# Altium schematic sheet transmitter.SchDoc
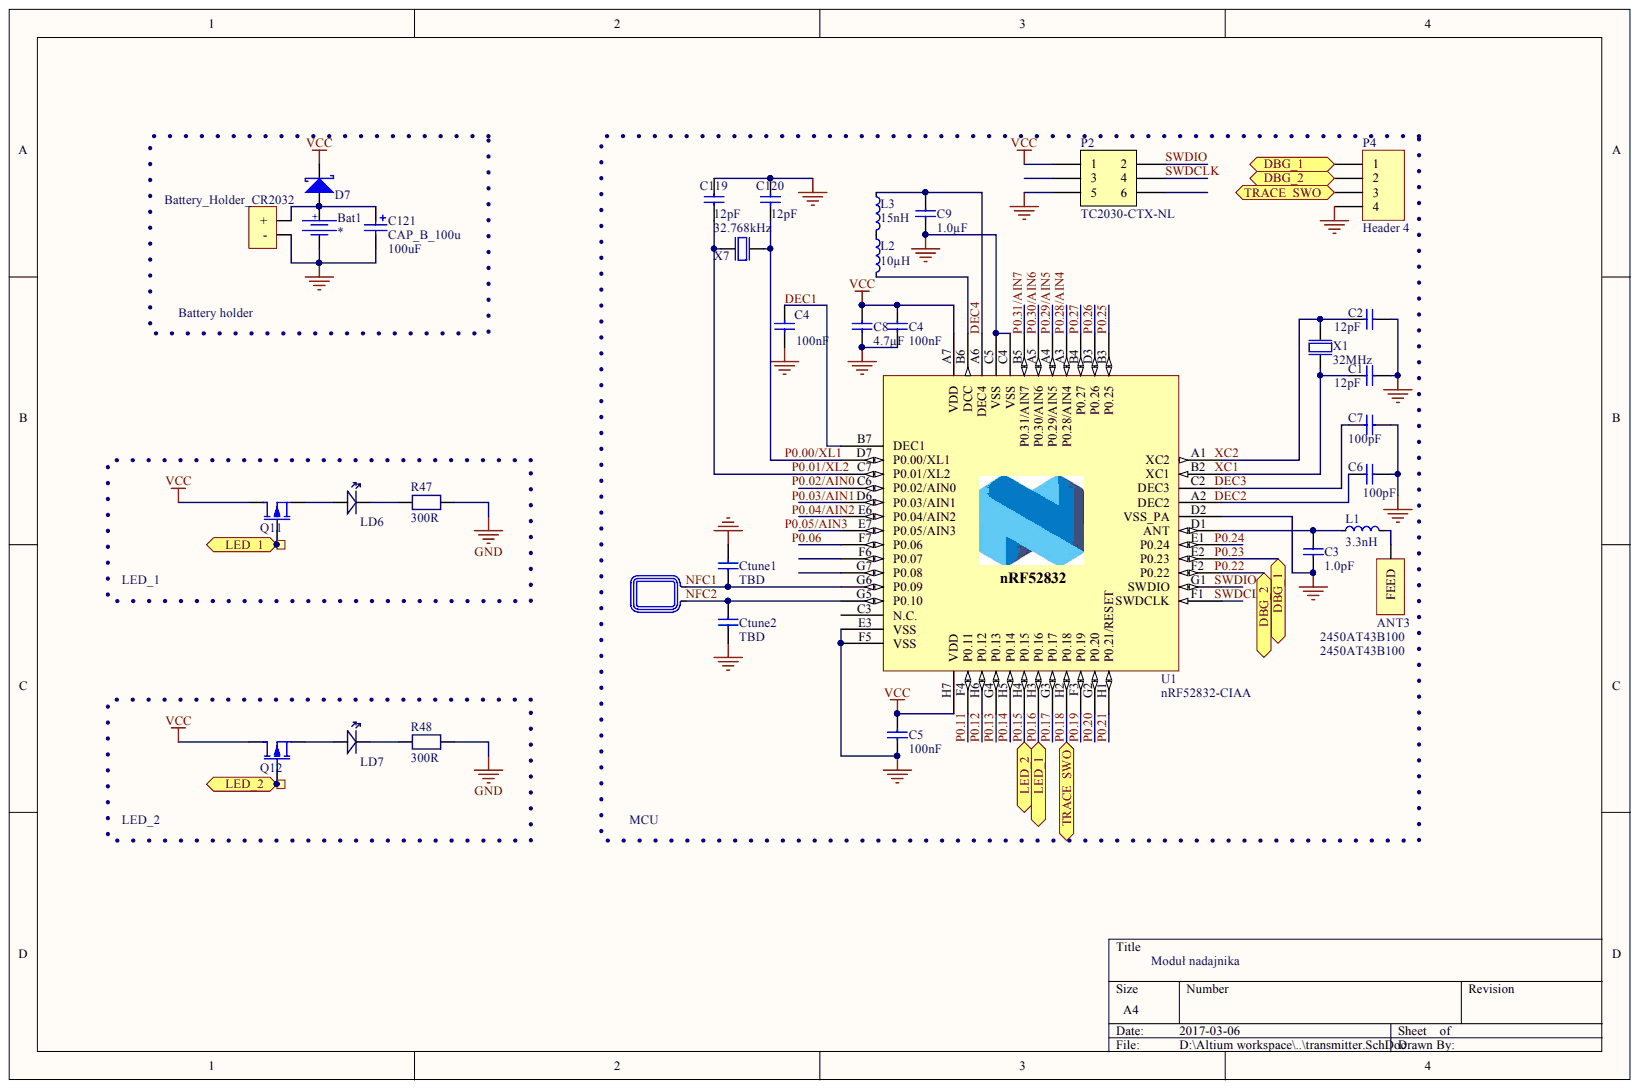
[diagram p0: transmitter.SchDoc]

## Parts
33  Ø1.0mm,SMD  [TP_SMD_Ø1.0mm]  (value not drawn)  (no BOM)
34  Ø1.0mm,SMD  [TP_SMD_Ø1.0mm]  (value not drawn)  (no BOM)
A1  NFC Antenna  [TP_2x1mm_TH]  (value not drawn)  (no BOM)
ANT3  2450AT43B100  [2450AT43B100]
Bat1  (no value)  [CR1632_HOLDER_SMD]
C1  12pF  [CAPC0603X03L]
C2  12pF  [CAPC0603X03L]
C3  1.0pF  [CAPC0603X03L]
C4  100nF  [CAPC0603X03L]
C4  100nF  [CAPC0603X03L]
C5  100nF  [CAPC0603X03L]
C6  100pF  [CAPC0603X03L]
C7  100pF  [CAPC0603X03L]
C8  4.7µF  [CAPC1608X06L]
C9  1.0µF  [CAPC1005X04L]
C119  12pF  [CAPC0603X03L]
C120  12pF  [CAPC0603X03L]
C121  CAP_B_100u  value 100uF  [B]
Ctune1  TBD  [CAPC0603X03L]
Ctune2  TBD  [CAPC0603X03L]
D7  Shottky  [DO-214-AA]  (value not drawn)
L1  3.3nH  [INDC0603X03L]
L2  10µH  [INDC1608X06L]
L3  15nH  [INDC1005X04L]
LD6  0603_LED  [LED_0603]  (value not drawn)
LD7  0603_LED  [LED_0603]  (value not drawn)
P2  TC2030-CTX-NL  [TC2030-IDC-NLFP]  (no BOM)
P4  Header 4  [HDR1X4]
Q11  RZE002P02TLTR-ND  [SOT-416]  (value not drawn)
Q12  RZE002P02TLTR-ND  [SOT-416]  (value not drawn)
R47  value 300R  [RES_0402]  (hidden comment: RES_0402_1k)
R48  value 300R  [RES_0402]  (hidden comment: RES_0402_1k)
U1  nRF52832-CIAA  [BGA50C40P7X8_295X322X50]
X1  32MHz  [BT-XTAL_2016]
X7  32.768kHz  [XTAL_2012]
  Battery_Holder_CR2032  [CR2032_holder]

## Ports
DBG_1, DBG_2, LED_1, LED_2, TRACE_SWO

## Nets
GND: Bat1.2 C1.1 C2.1 C3.1 C4.2 C5.2 C6.1 C7.2 C8.2 C9.1 C119.2 C120.2 C121.2 Ctune1.2 Ctune2.1 P2.5 P4.4 R47.1 R48.1 U1.C4(VSS) U1.C5(VSS) U1.D2(VSS_PA) U1.E3(VSS) U1.F5(VSS) X1.2 X1.4 .2
Net_1: Bat1.1 C121.1 D7.1 .1
VCC: C4.1 C5.1 C8.1 D7.2 P2.1 Q11.3 Q12.3 U1.A7(VDD) U1.H7(VDD)
DBG_1: P4.1 U1.E2(P0.23) ; port DBG_1
DBG_2: P4.2 U1.F2(P0.22) ; port DBG_2
DEC1: C4.1 U1.B7(DEC1)
DEC4: C9.2 L3.1 U1.A6(DEC4)
NFC1: A1.2 Ctune1.1 U1.G6(P0.09)
NFC2: A1.1 Ctune2.2 U1.G5(P0.10)
P0.00/XL1: C120.1 U1.D7(P0.00/XL1) X7.2
P0.01/XL2: C119.1 U1.C7(P0.01/XL2) X7.1
P0.11: U1.F4(P0.11)
P0.12: U1.H6(P0.12)
P0.13: U1.G4(P0.13)
P0.14: U1.H5(P0.14)
P0.15: 34.1 Q12.1 U1.H4(P0.15) ; port LED_2
P0.16: 33.1 Q11.1 U1.H3(P0.16) ; port LED_1
P0.17: U1.G3(P0.17)
P0.18: P4.3 U1.H2(P0.18) ; port TRACE_SWO
P0.19: U1.F3(P0.19)
P0.20: U1.G2(P0.20)
P0.21: U1.H1(P0.21/RESET)
P0.25: U1.B3(P0.25)
P0.26: U1.D3(P0.26)
P0.27: U1.B4(P0.27)
P0.28/AIN4: U1.A3(P0.28/AIN4)
P0.29/AIN5: U1.A4(P0.29/AIN5)
P0.30/AIN6: U1.A5(P0.30/AIN6)
P0.31/AIN7: U1.B5(P0.31/AIN7)
SWDCLK: P2.4
SWDIO: P2.2
NetANT3_1: ANT3.1 L1.2
NetC1_2: C1.2 U1.B2(XC1) X1.1
NetC2_2: C2.2 U1.A1(XC2) X1.3
NetC3_2: C3.2 L1.1 U1.D1(ANT)
NetC6_2: C6.2 U1.A2(DEC2)
NetC7_1: C7.1 U1.C2(DEC3)
NetL2_1: L2.1 L3.2
NetL2_2: L2.2 U1.B6(DCC)
NetLD6_1: LD6.1 Q11.2
NetLD6_2: LD6.2 R47.2
NetLD7_1: LD7.1 Q12.2
NetLD7_2: LD7.2 R48.2
NetP2_3: P2.3
NetP2_6: P2.6
NetU1_C3: U1.C3(N.C.)
NetU1_C6: U1.C6(P0.02/AIN0)
NetU1_D6: U1.D6(P0.03/AIN1)
NetU1_E1: U1.E1(P0.24)
NetU1_E6: U1.E6(P0.04/AIN2)
NetU1_E7: U1.E7(P0.05/AIN3)
NetU1_F1: U1.F1(SWDCLK)
NetU1_F7: U1.F7(P0.06)
NetU1_G1: U1.G1(SWDIO)
DEC2:
DEC3:
P0.02/AIN0:
P0.03/AIN1:
P0.04/AIN2:
P0.05/AIN3:
P0.06:
P0.22:
P0.23:
P0.24:
XC1:
XC2:

Not connected: U1 F6(P0.07) G7(P0.08)

## Library data of the parts
33 34 = TESTPAD: 1.0mm circular SMD testpad; Manufacturer: Nordic Semiconductor; Artikkelnr Noca: N.A.; LatestRevisionDate: 2013-06-11; Library Name: pca20006.IntLib; Part Number: TP_SMD_Ø1.0MM; Pin Count: 1; Published: 2013-06-11; Value: Ø1.0mm,SMD; Publisher: Nordic Semiconductor
A1 = NFC_ANT_TP: Artikkelnr Noca: N.A.; Database Table Name: Antennas$; Artikkelnr Simpro: N.A.; Description: NFC Antenna; Footprint Doc: TP_2x1mm_TH; LatestRevisionDate: 2016-02-23; Library Name: Nordic Passive DB.DbLib; Part Number: NFC_ANT_TP; Pin Count: 2; Published: 2016-02-23; Value: NFC Antenna; Publisher: Nordic Semiconductor
Bat1 = Bat Holder CR1632 SMD: 2nd source part number: 165-0697; Manufacturer: Keystone; Manufacturer Part Number: 3012; Artikkelnr Noca: N.A.; Database Table Name: Connectors$; 2nd source Supplier: Farnell; Component Kind: Standard; Datasheet: http://www.keyelco.com/product-pdf.cfm?p=790; Description: Bat Holder CR1632 SMD; LatestRevisionDate: 2014-01-13; Library Name: Nordic Passive DB.DbLib; Part Number: Bat Holder CR1632 SMD; Pin Count: 3; Published: 2014-01-13; Supplier: Digi-Key; Supplier part number: 3012K-ND; Value: Bat Holder CR1632; Publisher: Nordic Semiconductor
C1 C2 C119 C120 = C0201-12p: Capacitor, NP0, ±2%; Artikkelnr Noca: N.A.; Database Table Name: Capacitors$; Note: 50V; Artikkelnr Simpro: N.A.; Component Kind: Standard; Footprint Doc: 0201; LatestRevisionDate: 2014-11-13; Library Name: Nordic Passive DB.DbLib; Part Number: C0201-12p; Pin Count: 2; Published: 2014-11-13; Value: 12pF; Publisher: Nordic Semiconductor
C3 = C0201-1.0p: Capacitor, NP0, ±5%; Artikkelnr Noca: N.A.; Database Table Name: Capacitors$; Note: Chip Cap 1%; Artikkelnr Simpro: N.A.; Component Kind: Standard; Footprint Doc: 0201; LatestRevisionDate: 2014-11-13; Library Name: Nordic Passive DB.DbLib; Part Number: C0201-1.0p; Pin Count: 2; Published: 2014-11-13; Value: 1.0pF; Publisher: Nordic Semiconductor
C4 C4 C5 = C0201-100n: Capacitor, X7R, ±10%; Artikkelnr Noca: N.A.; Database Table Name: Capacitors$; Note: 16V; Artikkelnr Simpro: N.A.; Component Kind: Standard; Footprint Doc: 0201; LatestRevisionDate: 2014-11-13; Library Name: Nordic Passive DB.DbLib; Part Number: C0201-100n; Pin Count: 2; Published: 2014-11-13; Value: 100nF; Publisher: Nordic Semiconductor
C6 C7 = C0201-100p: Capacitor, NP0, ±5%; Artikkelnr Noca: N.A.; Database Table Name: Capacitors$; Note: Chip Cap 1%; Artikkelnr Simpro: N.A.; Component Kind: Standard; Footprint Doc: 0201; LatestRevisionDate: 2014-11-13; Library Name: Nordic Passive DB.DbLib; Part Number: C0201-100p; Pin Count: 2; Published: 2014-11-13; Value: 100pF; Publisher: Nordic Semiconductor
C8 = C0603-4µ7: Capacitor, X5R, ±10%; Artikkelnr Noca: 20069060; Artikkelnr Simpro: N.A.; Database Table Name: Capacitors$; Note: 6.3V; Component Kind: Standard; Footprint Doc: 0603; LatestRevisionDate: 2009-11-23; Library Name: Nordic Passive DB.DbLib; Part Number: C0603-4µ7; Pin Count: 2; Published: 2009-05-08; Value: 4.7µF; Publisher: Nordic Semiconductor
C9 = C0402-1µ0: Capacitor, X5R, ±5%; Artikkelnr Noca: 20039235; Artikkelnr Simpro: N.A.; Database Table Name: Capacitors$; Note: 6.3V; Component Kind: Standard; Footprint Doc: 0402; LatestRevisionDate: 2009-11-23; Library Name: Nordic Passive DB.DbLib; Part Number: C0402-1µ0; Pin Count: 2; Published: 2009-05-08; Value: 1.0µF; Publisher: Nordic Semiconductor
C121 = CAP_B_100u: Solid Tantalum Capacitor; Component Kind: Standard; Signal Integrity: T491A; Simulation: CAP; Supplier 1: TME; Supplier 2: TME; Supplier 3: Digi-Key; Supplier Part Number 1: TAJB107K006R; Supplier Part Number 2: TAJB107M006R; Supplier Part Number 3: 399-3688-2-ND; Library Name: ONEMETER.SchLib
Ctune1 Ctune2 = C0201-TBD: Capacitor, NP0, ±5%; Artikkelnr Noca: N.A.; Database Table Name: Capacitors$; Note: Chip Cap; Artikkelnr Simpro: N.A.; Component Kind: Standard; Footprint Doc: 0201; LatestRevisionDate: 2014-11-13; Library Name: Nordic Passive DB.DbLib; Part Number: C0201-TBD; Pin Count: 2; Published: 2014-11-13; Value: TBD; Publisher: Nordic Semiconductor
D7 = Shottky_diode: Component Kind: Standard; Library Name: Diodes.SchLib
L1 = L0201-3n3: High frequency chip inductor ±5%; Artikkelnr Noca: N.A.; Database Table Name: Inductors$; Artikkelnr Simpro: N.A.; Component Kind: Standard; Footprint Doc: 0201; LatestRevisionDate: 2013-04-10; Library Name: Nordic Passive DB.DbLib; Note: High frequency chip inductor ±5%; Part Number: L0201-3n3; Pin Count: 2; Published: 2013-04-10; Value: 3.3nH; Publisher: Nordic Semiconductor
L2 = L0603-10µ: Chip inductor, IDC,min = 50 mA, ±20%; 2nd source part number: 178-6105; Manufacturer: Taiyo Yuden; Manufacturer Part Number: LBMF1608T100K; Artikkelnr Noca: 33029314; Artikkelnr Simpro: N.A.; Database Table Name: Inductors$; Note: LBMF1608T100K 10µH 80mA 0603; 2nd source Supplier: Farnell; Component Kind: Standard; Datasheet: http://www.yuden.co.jp/ut/product/pdf/elb2_e.pdf; Footprint Doc: 0603; LatestRevisionDate: 2012-09-19; Library Name: Nordic Passive DB.DbLib; Part Number: L0603-10µ; Pin Count: 2; Published: 2010-02-24; Supplier: Digi-Key; Supplier part number: 587-1714-1-ND; Value: 10µH; Publisher: Nordic Semiconductor
L3 = L0402-15n: High frequency chip inductor ±10%; 2nd source part number: 658-1328; Manufacturer: Taiyo Yuden; Manufacturer Part Number: HK100515NJ-T; Artikkelnr Noca: 33029323; Artikkelnr Simpro: N.A.; Database Table Name: Inductors$; 2nd source Supplier: Farnell; Component Kind: Standard; Datasheet: http://www.yuden.co.jp/productdata/catalog/en/mlci04_e.pdf; Footprint Doc: 0402; LatestRevisionDate: 2012-09-19; Library Name: Nordic Passive DB.DbLib; Note: High frequency chip inductor ±10%; Part Number: L0402-15n; Pin Count: 2; Published: 2010-07-12; Supplier: Digi-Key; Supplier part number: 587-1521-1-ND; Value: 15nH; Publisher: Nordic Semiconductor
LD6 LD7 = LED_0603_Blue: Component Kind: Standard; Supplier 1: Digi-Key; Supplier 2: TME; Supplier 3: TME; Supplier 4: TME; Supplier 5: TME; Supplier Part Number 1: 160-1647-2-ND; Supplier Part Number 2: LL-S192PBC-B4-1B; Supplier Part Number 3: LL-S194PBC-B4-1B; Supplier Part Number 4: OF-SMD1608B; Supplier Part Number 5: OSB50603C1D; Library Name: ONEMETER.SchLib
P2 = TC2030-CTX-NL: CBL PLUG-OF-NAILS 6-PIN; Manufacturer: Tag-Connect LLC; Artikkelnr Noca: N.A.; Database Table Name: Connectors$; Datasheet: http://www.tag-connect.com/Materials/TC2030-IDC-NL.pdf; LatestRevisionDate: 2013-08-08; Library Name: Nordic Passive DB.DbLib; Manufacturer Part Number: TC2030-CTX-NL; Part Number: TC2030-CTX-NL; Pin Count: 6; Published: 2013-08-08; Supplier: Tag-Connect; Supplier part number: TC2030-CTX-NL; Value: TC2030-CTX-NL; Publisher: Nordic Semiconductor
P4 = Header 4: Header, 4-Pin; Component Kind: Standard; LatestRevisionDate: 17-Jul-2002; LatestRevisionNote: Re-released for DXP Platform.; Library Name: Miscellaneous Connectors.IntLib; Signal Integrity: Connector; Publisher: Altium Limited
U1 = nRF52832-CIAA: Multi-protocol Bluetooth Low Energy, ANT and 2.4GHz proprietary system-on-chip; Manufacturer: Nordic Semiconductor; Artikkelnr Noca: N.A.; Database Table Name: nRF Devices$; Artikkelnr Simpro: N.A.; Component Kind: Standard; Footprint Doc: WLCSP_C50; LatestRevisionDate: 2016-03-11; Library Name: Nordic Active DB.DbLib; Manufacturer Part Number: nRF52832-CIAA; Part Number: nRF52832-CIAA; Part number IPS: N.A.; Pin Count: 54; Published: 2016-03-11; Supplier: Nordic Semiconductor; Value: nRF52832-CIAA; Publisher: Nordic Semiconductor
X1 = XTAL_SMD2016_32MHz_8pF_Epson: XTAL SMD 2016, 32MHz, Cl=8pF, Total Tol: ±40ppm; Manufacturer: Epson Toyocom; Manufacturer Part Number: Q22FA12800025; Artikkelnr Noca: N.A.; Database Table Name: Crystal_Osc$; Note: XTAL SMD 32.000MHz; Artikkelnr Simpro: N.A.; Component Kind: Standard; Datasheet: http://www.epsondevice.com/docs/qd/en/DownloadServlet?id=ID000449; Footprint Doc: XTAL_2016; LatestRevisionDate: 2014-11-27; Library Name: Nordic Discrete DB.DbLib; Part Number: XTAL_SMD2016_32MHz_8pF_Epson; Pin Count: 4; Published: 2012-03-09; Supplier: Farnell; Supplier part number: 171-2806; Value: 32MHz; Publisher: Nordic Semiconductor
X7 = XTAL_SMD2012_32.768kHz_9pF_Seiko: XTAL SMD 2012, 32.768kHz, Cl=9pF, Total Tol: ±50ppm; Manufacturer: Seiko; Manufacturer Part Number: SC20S-9.0PF20PPM; Artikkelnr Noca: N.A.; Database Table Name: Crystal_Osc$; Note: XTAL SMD 32.768kHz, 2012; Artikkelnr Simpro: N.A.; Component Kind: Standard; Datasheet: http://www.sii.co.jp/en/quartz/files/2013/03/file_PRODUCT_MASTER_50811_GRAPHIC03.pdf; Footprint Doc: XTAL_2012; LatestRevisionDate: 2015-10-15; Library Name: Nordic Discrete DB.DbLib; Part Number: XTAL_SMD2012_32.768kHz_9pF_Seiko; Pin Count: 2; Published: 2015-10-15; Value: 32.768kHz; Publisher: Nordic Semiconductor
ANT3 = 2450AT43B100: Component Kind: Standard; Supplier 1: Mouser; Supplier 2: Farnell; Supplier 3: Farnell; Supplier 4: Digi-Key; Supplier 5: Digi-Key; Supplier 6: Digi-Key; Supplier Part Number 1: 609-2450AT43B100E; Supplier Part Number 2: 2148524; Supplier Part Number 3: 2148524RL; Supplier Part Number 4: 712-1010-2-ND; Supplier Part Number 5: 712-1010-1-ND; Supplier Part Number 6: 712-1010-6-ND; Value: 2450AT43B100; Library Name: ONEMETER.SchLib
Q11 Q12 = RZE002P02TLTR-ND: Component Kind: Standard; Supplier 1: Digi-Key; Supplier 2: Digi-Key; Supplier 3: Digi-Key; Supplier Part Number 1: RZE002P02TLTR-ND; Supplier Part Number 2: RZE002P02TLCT-ND; Supplier Part Number 3: RZE002P02TLDKR-ND; Value: RZE002P02TL; Library Name: ONEMETER.SchLib
R47 R48 = RES_0402_1k: Component Kind: Standard; Supplier 1: Digi-Key; Supplier 2: Farnell; Supplier 3: Mouser; Supplier Part Number 1: 311-1.0KJRTR-ND; Supplier Part Number 2: 1358043; Supplier Part Number 3: 603-RC0402FR-071KL; Library Name: ONEMETER.SchLib
 = Battery_Holder_CR2032: Component Kind: Standard; Library Name: Terminal_block.SchLib
